# Altium schematic sheet main.schdoc
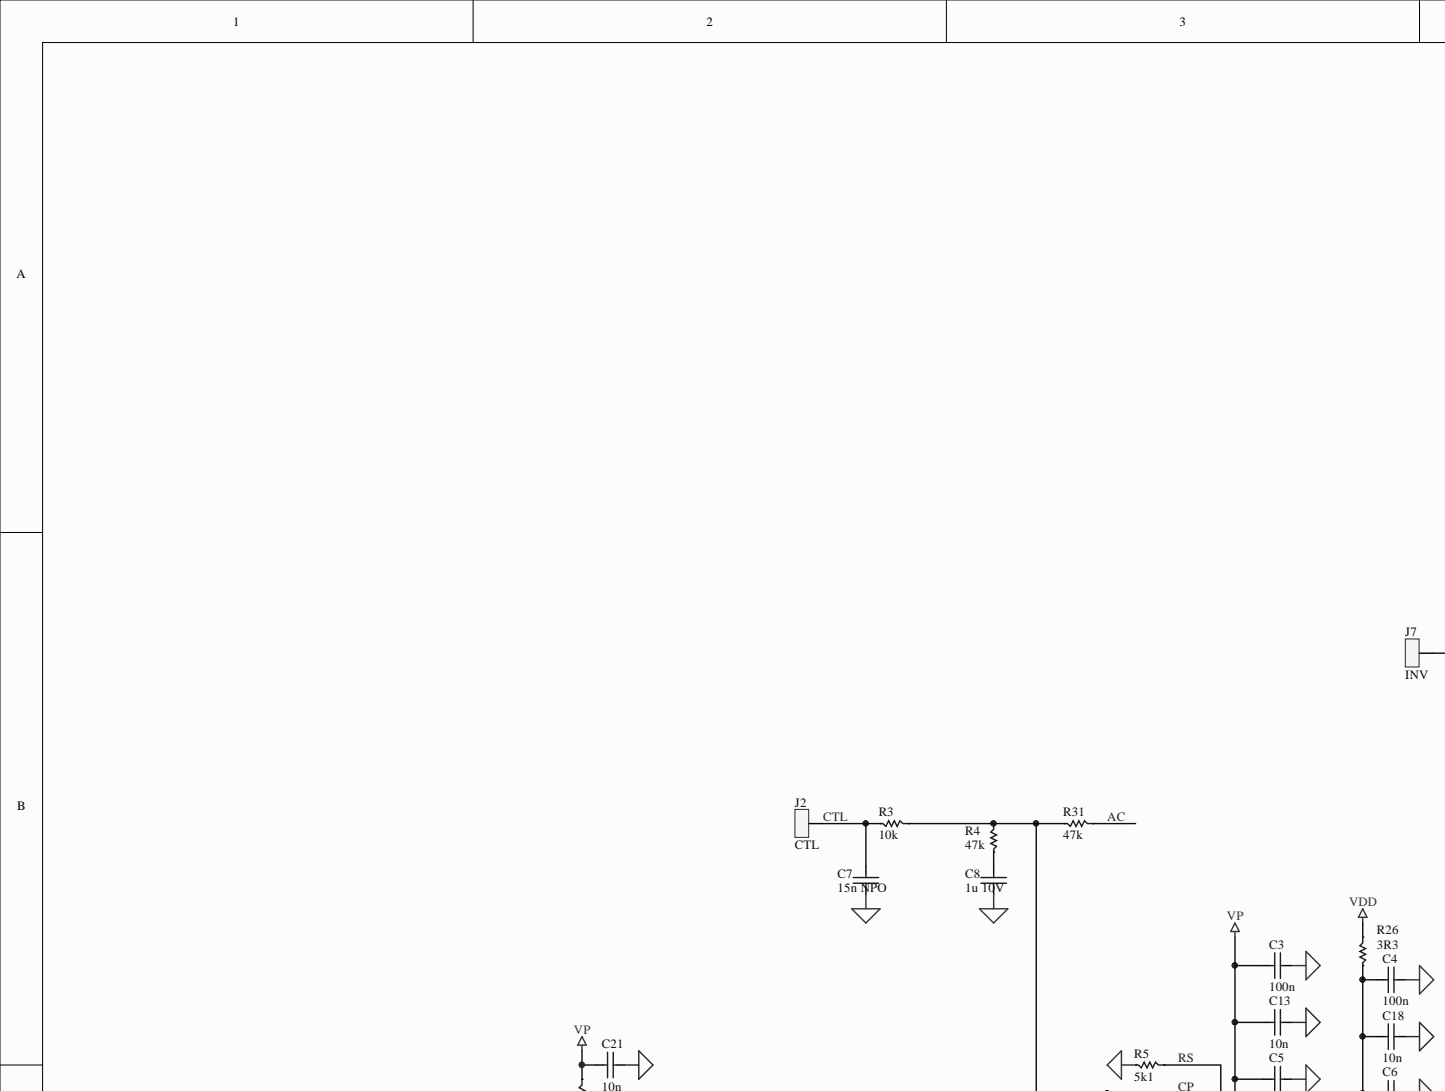
[diagram p0: main.schdoc, top left]
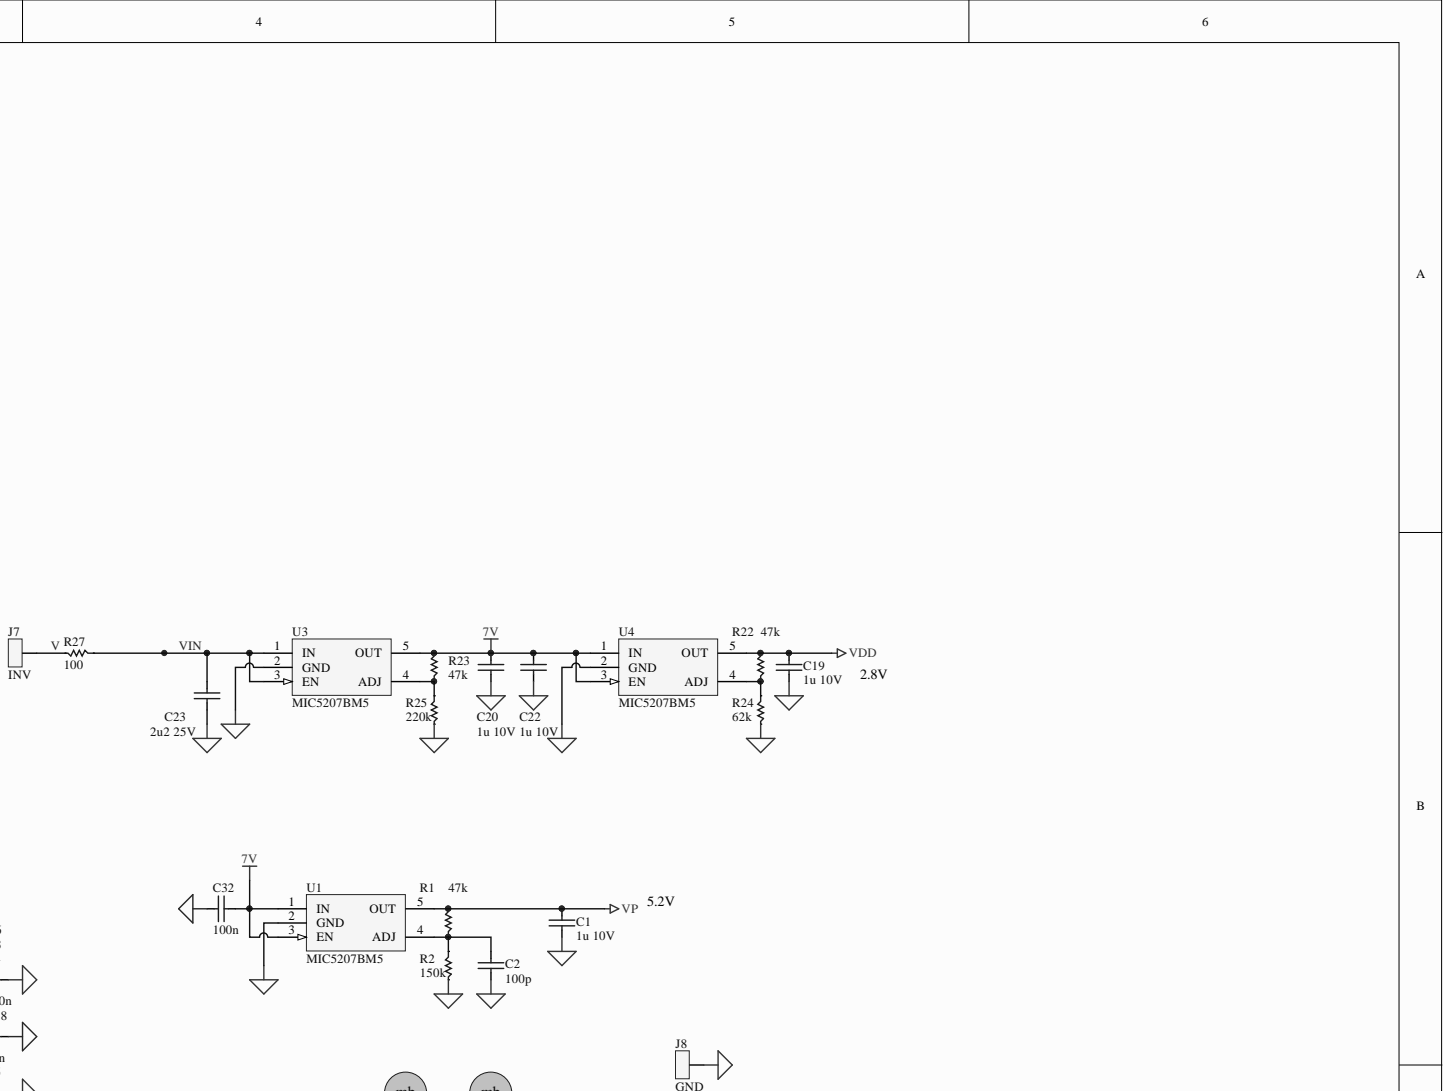
[diagram p1: main.schdoc, top right]
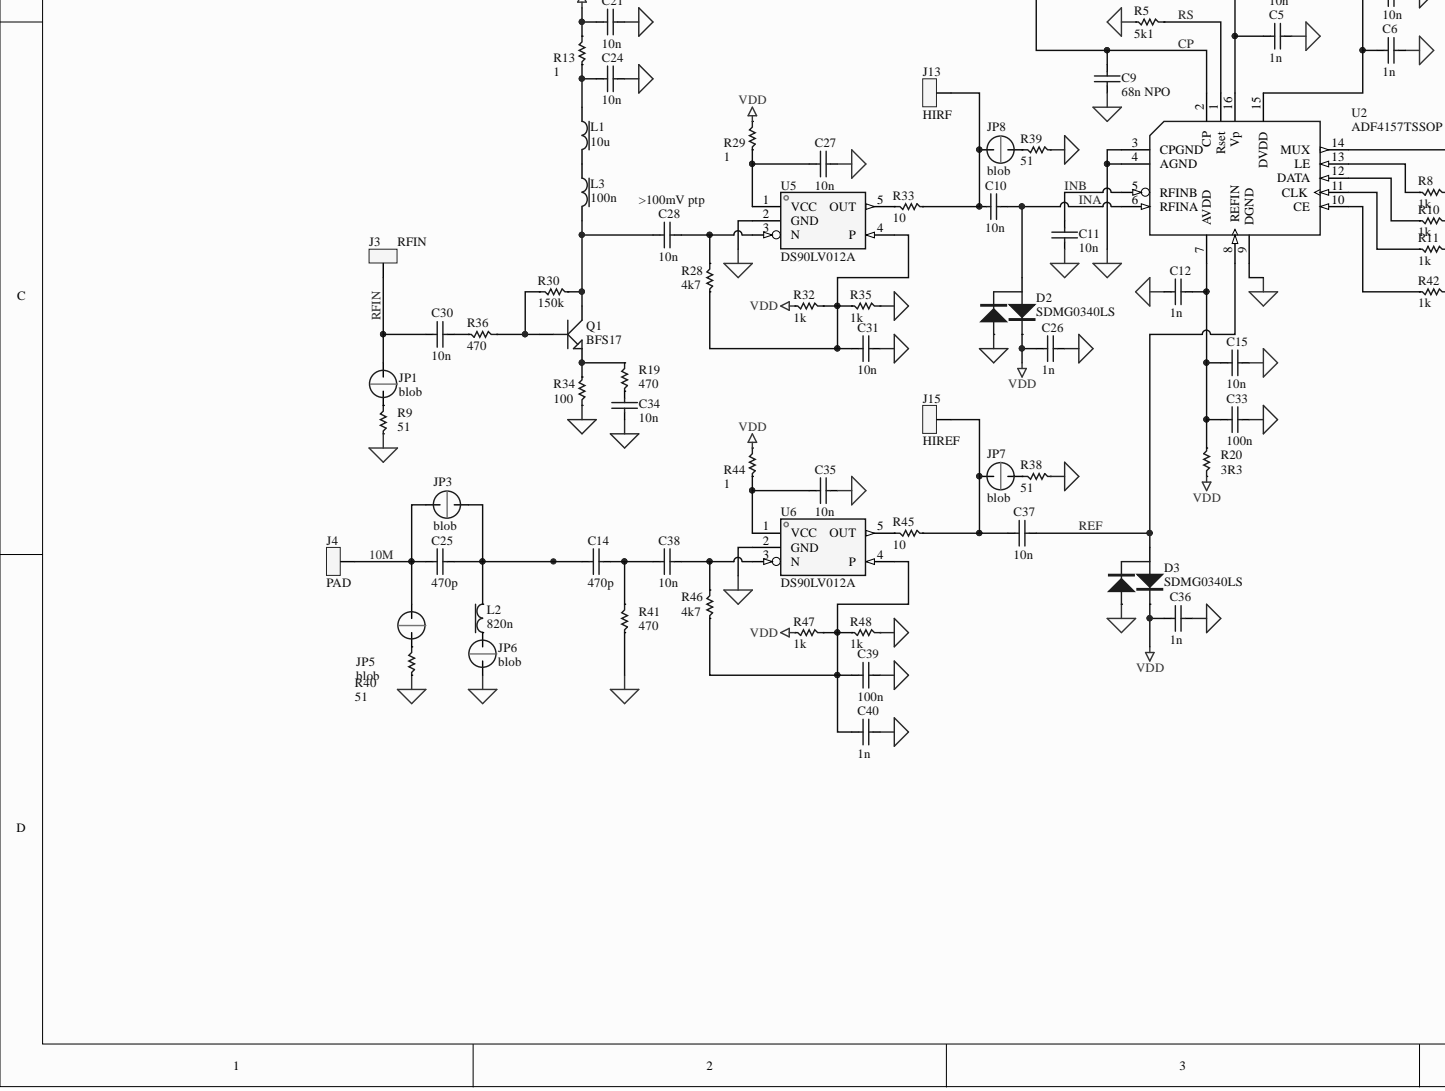
[diagram p2: main.schdoc, bottom left]
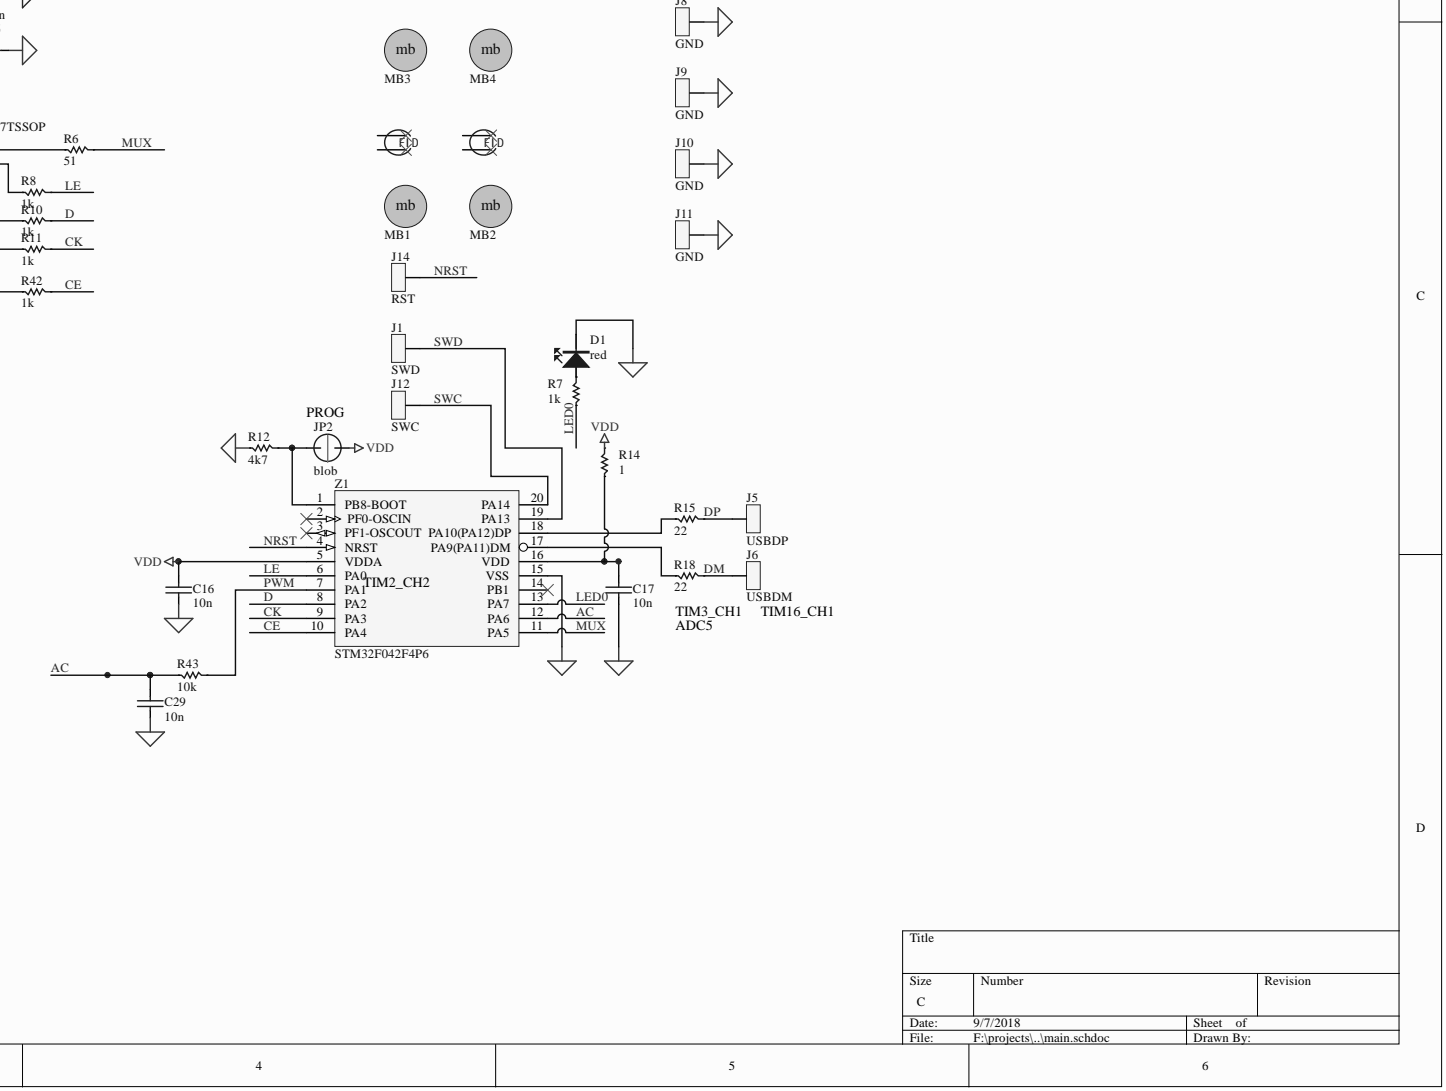
[diagram p3: main.schdoc, bottom right]

## Parts
C1  1u 10V  [0603C]
C2  100p  [0603C]
C3  100n  [0603C]
C4  100n  [0603C]
C5  1n  [0402C]
C6  1n  [0402C]
C7  15n NPO  [1206C]
C8  1u 10V  [1206C]
C9  68n NPO  [1206C]
C10  10n  [0603C]
C11  10n  [0603C]
C12  1n  [0402C]
C13  10n  [0603C]
C14  470p  [0603C]
C15  10n  [0603C]
C16  10n  [0603C]
C17  10n  [0603C]
C18  10n  [0603C]
C19  1u 10V  [0603C]
C20  1u 10V  [0603C]
C21  10n  [0603C]
C22  1u 10V  [0603C]
C23  2u2 25V  [0805C]
C24  10n  [0603C]
C25  470p  [0603C]
C26  1n  [0402C]
C27  10n  [0603C]
C28  10n  [0603C]
C29  10n  [0603C]
C30  10n  [0603C]
C31  10n  [0603C]
C32  100n  [0603C]
C33  100n  [0603C]
C34  10n  [0603C]
C35  10n  [0603C]
C36  1n  [0402C]
C37  10n  [0603C]
C38  10n  [0603C]
C39  100n  [0603C]
C40  1n  [0603C]
D1  red  [0603LED]
D2  SDMG0340LS  [SOT-323]
D3  SDMG0340LS  [SOT-323]
FID1  FIDUCIAL  [FIDUCIAL]  (value not drawn)
FID2  FIDUCIAL  [FIDUCIAL]  (value not drawn)
J1  SWD  [GPPAD3R]
J2  CTL  [GPPAD3R]
J3  RFIN  [GPPAD3R]
J4  PAD  [GPPAD3R]
J5  USBDP  [GPPAD3R]
J6  USBDM  [GPPAD3R]
J7  INV  [GPPAD3R]
J8  GND  [GPPAD3R]
J9  GND  [GPPAD3R]
J10  GND  [GPPAD3R]
J11  GND  [GPPAD3R]
J12  SWC  [GPPAD3R]
J13  HIRF  [GPPAD3R]
J14  RST  [GPPAD3R]
J15  HIREF  [GPPAD]
JP1  blob  [BLOBJUMPER]
JP2  blob  [BLOBJUMPER]
JP3  blob  [BLOBJUMPER]
JP5  blob  [BLOBJUMPER]
JP6  blob  [BLOBJUMPER]
JP7  blob  [BLOBJUMPER]
JP8  blob  [BLOBJUMPER]
L1  10u  [0603L]
L2  820n  [0603L]
L3  100n  [0603L]
MB1  mousebite  [mousebite]  (value not drawn)
MB2  mousebite  [mousebite]  (value not drawn)
MB3  mousebite  [mousebite]  (value not drawn)
MB4  mousebite  [mousebite]  (value not drawn)
Q1  BFS17  [SOT-323]
R1  47k  [0603R]
R2  150k  [0603R]
R3  10k  [0603R]
R4  47k  [0603R]
R5  5k1  [0603R]
R6  51  [0603R]
R7  1k  [0603R]
R8  1k  [0603R]
R9  51  [0603R]
R10  1k  [0603R]
R11  1k  [0603R]
R12  4k7  [0603R]
R13  1  [0603R]
R14  1  [0603R]
R15  22  [0603R]
R18  22  [0603R]
R19  470  [0603R]
R20  3R3  [0603R]
R22  47k  [0603R]
R23  47k  [0603R]
R24  62k  [0603R]
R25  220k  [0603R]
R26  3R3  [0603R]
R27  100  [0805R]
R28  4k7  [0603R]
R29  1  [0603R]
R30  150k  [0603R]
R31  47k  [0603R]
R32  1k  [0603R]
R33  10  [0603R]
R34  100  [0603R]
R35  1k  [0603R]
R36  470  [0603R]
R38  51  [0603R]
R39  51  [0603R]
R40  51  [0603R]
R41  470  [0603R]
R42  1k  [0603R]
R43  10k  [0603R]
R44  1  [0603R]
R45  10  [0603R]
R46  4k7  [0603R]
R47  1k  [0603R]
R48  1k  [0603R]
U1  MIC5207BM5  [SOT23-5]
U2  ADF4157TSSOP  [TSSOP16]
U3  MIC5207BM5  [SOT23-5]
U4  MIC5207BM5  [SOT23-5]
U5  DS90LV012A  [SOT23-5]
U6  DS90LV012A  [SOT23-5]
Z1  STM32F042F4P6  [TSSOP20_4.4mm]

## Nets
7V: C20.1 C22.1 C32.2 R23.2 U1.1(IN) U1.3(EN) U3.5(OUT) U4.1(IN) U4.3(EN)
GND: C1.2 C2.2 C3.2 C4.2 C5.2 C6.2 C7.2 C8.2 C9.2 C11.2 C12.1 C13.2 C15.2 C16.2 C17.2 C18.2 C19.2 C20.2 C21.2 C22.2 C23.2 C24.2 C26.2 C27.2 C29.2 C31.2 C32.1 C33.2 C34.1 C35.2 C36.2 C39.2 C40.2 D1.K D2.1 D3.1 J8.1 J9.1 J10.1 J11.1 JP6.2 R2.2 R5.2 R9.2 R12.2 R24.2 R25.2 R34.1 R35.1 R38.2 R39.2 R40.2 R41.2 R48.1 U1.2(GND) U2.3(CPGND) U2.4(AGND) U2.9(DGND) U3.2(GND) U4.2(GND) U5.2(GND) U6.2(GND) Z1.15(VSS)
VDD: C16.1 C19.1 C26.1 C36.1 D2.2 D3.2 JP2.1 R14.1 R20.1 R22.2 R26.1 R29.1 R32.2 R44.1 R47.2 U4.5(OUT) Z1.5(VDDA)
VP: C1.1 C3.1 C5.1 C13.1 C21.1 R1.2 R13.1 U1.5(OUT) U2.16(Vp)
10M: C25.1 J4.1 JP3.1 JP5.1
AC: C29.1 R31.1 R43.2 Z1.12(PA6)
CE: R42.1 Z1.10(PA4)
CK: R11.1 Z1.9(PA3)
CP: C9.1 R3.1 R4.1 R31.2 U2.2(CP)
CTL: C7.1 J2.1 R3.2
D: R10.1 Z1.8(PA2)
DM: J6.1 R18.1
DP: J5.1 R15.1
INA: C10.2 D2.3 U2.6(RFINA)
INB: C11.1 U2.5(RFINB)
LE: R8.1 Z1.6(PA0)
LED0: R7.2 Z1.13(PA7)
MUX: R6.1 Z1.11(PA5)
NRST: J14.1 Z1.4(NRST)
PWM: R43.1 Z1.7(PA1)
REF: C37.2 D3.3 U2.8(REFIN)
RFIN: C30.1 J3.1 JP1.1
RS: R5.1 U2.1(Rset)
SWC: J12.1 Z1.20(PA14)
SWD: J1.1 Z1.19(PA13)
V: J7.1 R27.2
VIN: C23.1 R27.1 U3.1(IN) U3.3(EN)
NetC2_1: C2.1 R1.1 R2.1 U1.4(ADJ)
NetC4_1: C4.1 C6.1 C18.1 R26.2 U2.15(DVDD)
NetC8_1: C8.1 R4.2
NetC10_1: C10.1 J13.1 JP8.1 R33.2
NetC12_2: C12.2 C15.1 C33.1 R20.2 U2.7(AVDD)
NetC14_1: C14.1 C25.2 JP3.2 L2.2
NetC14_2: C14.2 C38.1 R41.1
NetC17_1: C17.1 R14.2 Z1.16(VDD)
NetC24_1: C24.1 L1.1 R13.2
NetC27_1: C27.1 R29.2 U5.1(VCC)
NetC28_1: C28.1 L3.2 Q1.3 R30.2
NetC28_2: C28.2 R28.1 U5.3(N)
NetC30_2: C30.2 R36.2
NetC31_1: C31.1 R28.2 R32.1 R35.2 U5.4(P)
NetC34_2: C34.2 R19.2
NetC35_1: C35.1 R44.2 U6.1(VCC)
NetC37_1: C37.1 J15.1 JP7.1 R45.2
NetC38_2: C38.2 R46.1 U6.3(N)
NetC39_1: C39.1 C40.1 R46.2 R47.1 R48.2 U6.4(P)
NetD1_A: D1.A R7.1
NetJP1_2: JP1.2 R9.1
NetJP2_2: JP2.2 R12.1 Z1.1(PB8-BOOT)
NetJP5_2: JP5.2 R40.1
NetJP6_1: JP6.1 L2.1
NetJP7_2: JP7.2 R38.1
NetJP8_2: JP8.2 R39.1
NetL1_2: L1.2 L3.1
NetQ1_1: Q1.1 R30.1 R36.1
NetQ1_2: Q1.2 R19.1 R34.2
NetR6_2: R6.2 U2.14(MUX)
NetR8_2: R8.2 U2.13(LE)
NetR10_2: R10.2 U2.12(DATA)
NetR11_2: R11.2 U2.11(CLK)
NetR15_2: R15.2 Z1.18(PA10(PA12)DP)
NetR18_2: R18.2 Z1.17(PA9(PA11)DM)
NetR22_1: R22.1 R24.1 U4.4(ADJ)
NetR23_1: R23.1 R25.1 U3.4(ADJ)
NetR33_1: R33.1 U5.5(OUT)
NetR42_2: R42.2 U2.10(CE)
NetR45_1: R45.1 U6.5(OUT)

Not connected: FID1 0 1; FID2 0 1; Z1 2(PF0-OSCIN) 3(PF1-OSCOUT) 14(PB1)

## Library data of the parts
C1-C15 C18-C23 C25 C26 C28-C33 C36-C40 = caps: Component Kind: Standard; Library Name: glen_sch.SCHLIB
C16 C17 C24 C27 C34 C35 = casp: Component Kind: Standard; Library Name: glen_sch.SCHLIB
D1 = LEDS: Component Kind: Standard; Library Name: glen_sch.SCHLIB
D2 D3 = Diode_Dual_series: diode small two in series; Component Kind: Standard; Library Name: glen_sch.SCHLIB
FID1 FID2 = FIDUCIAL: fiducial mark; Component Kind: Standard; Library Name: glen_sch.SCHLIB
J1-J15 = TESTPOINT: test point; Component Kind: Standard; Library Name: glen_sch.SCHLIB
JP1-JP3 JP5-JP8 = BLOBJUMPER: blob jumper; Component Kind: Standard; Library Name: glen_sch.SCHLIB
L1 L3 = INDUCTORSHORT: Component Kind: Standard; Library Name: glen_sch.SCHLIB
L2 = INDSMALL: inductor small; Component Kind: Standard; Library Name: glen_sch.SCHLIB
Q1 = NPN1-SOT-23: Component Kind: Standard; Library Name: glen_sch.SCHLIB
R1-R15 R18-R20 R22-R36 R38-R48 = ress: resistor small; Component Kind: Standard; Library Name: glen_sch.SCHLIB
U1 U3 U4 = MIC5207BM5: adjustable low dropout regulator; Component Kind: Standard; Library Name: glen_sch.SCHLIB
U2 = ADF4157TSSOP: freq synthesiser; Component Kind: Standard; Library Name: glen_sch.SCHLIB
U5 U6 = FIN1002M5: LVDS recv single; Component Kind: Standard; Library Name: glen_sch.SCHLIB
Z1 = STM32F042x4: STM32 M0 TSSOP; Component Kind: Standard; Library Name: glen_sch.SCHLIB
MB1-MB4 = mousebite: Component Kind: Standard; Description: mousebite; Library Name: glen_sch.SCHLIB
